# Altium schematic sheet STM32 DIY 飞控板V3.SchDoc
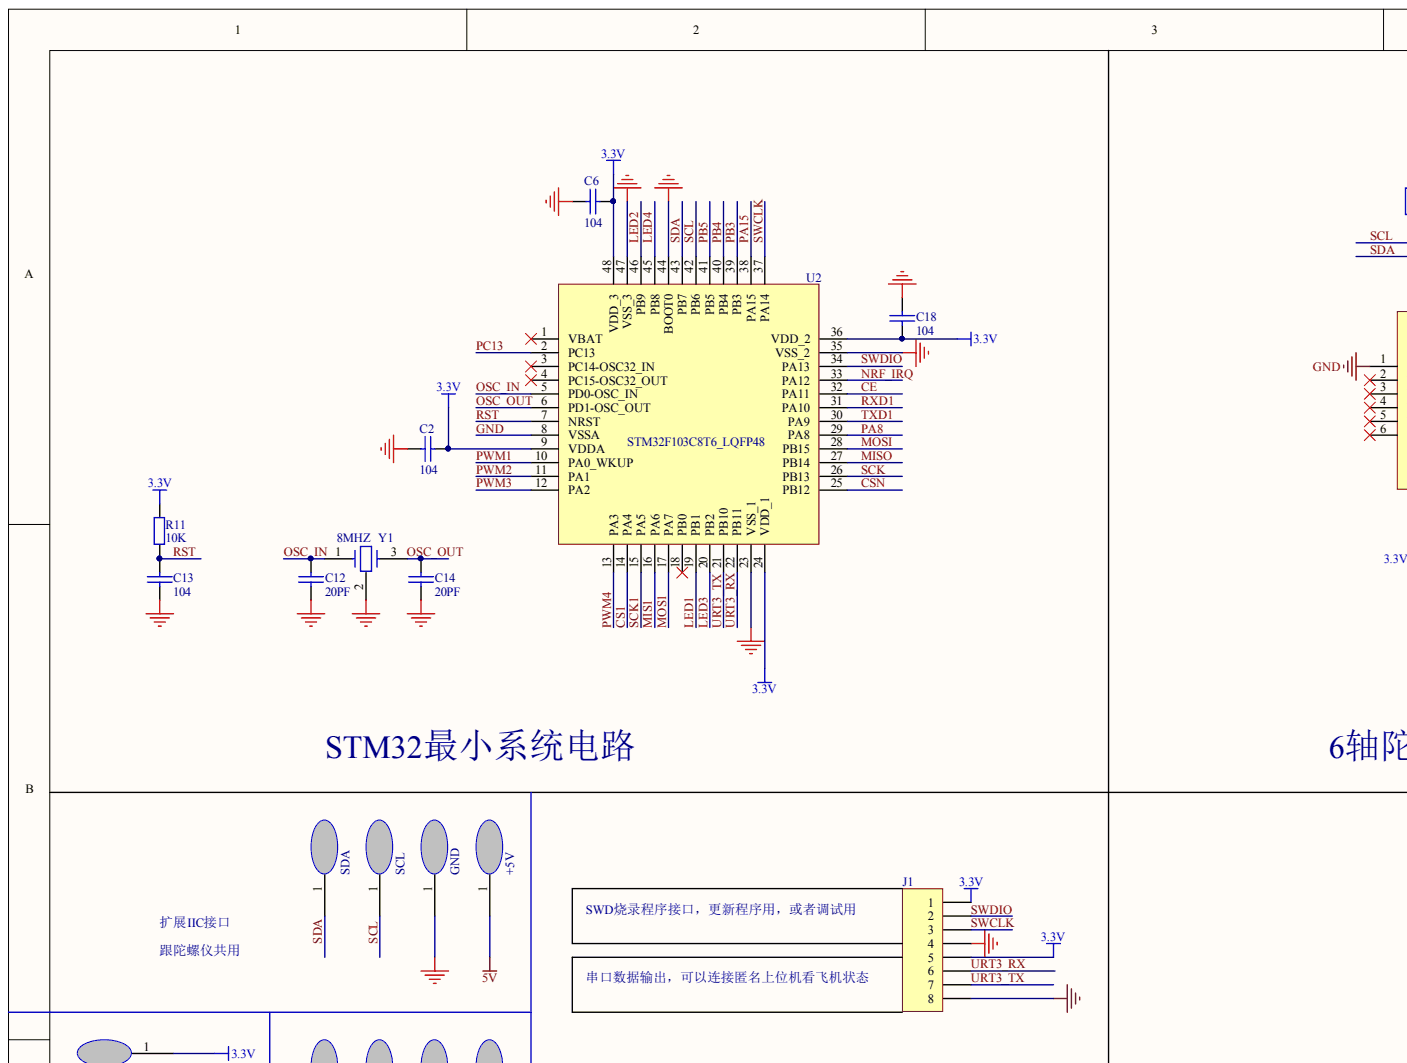
[diagram p0: STM32 DIY 飞控板V3.SchDoc, top left]
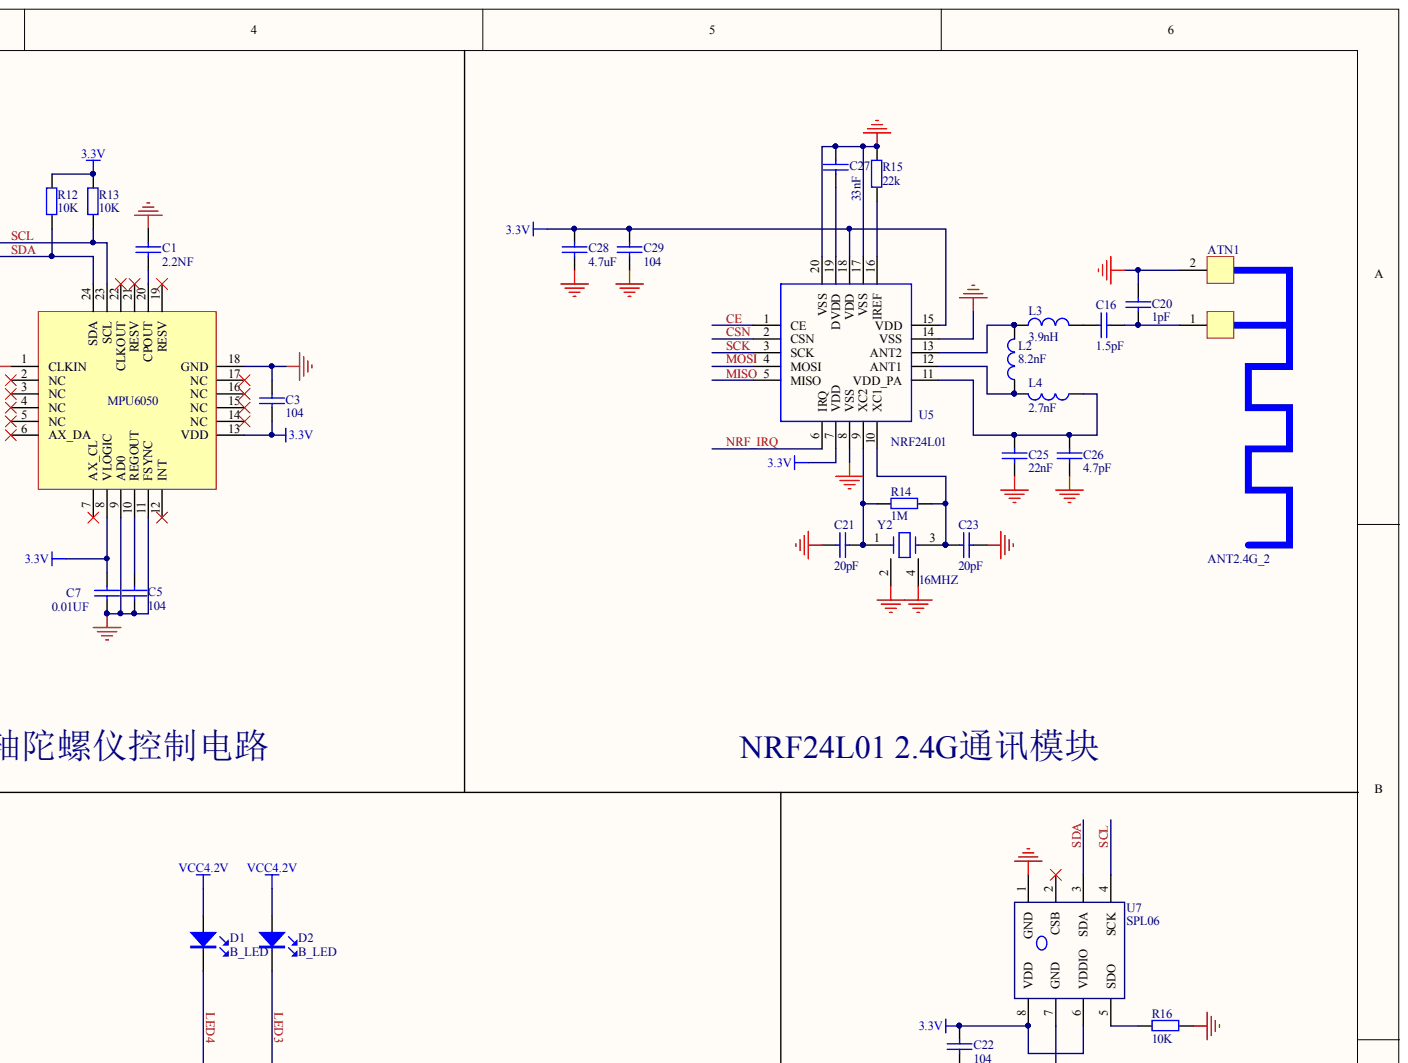
[diagram p1: STM32 DIY 飞控板V3.SchDoc, top right]
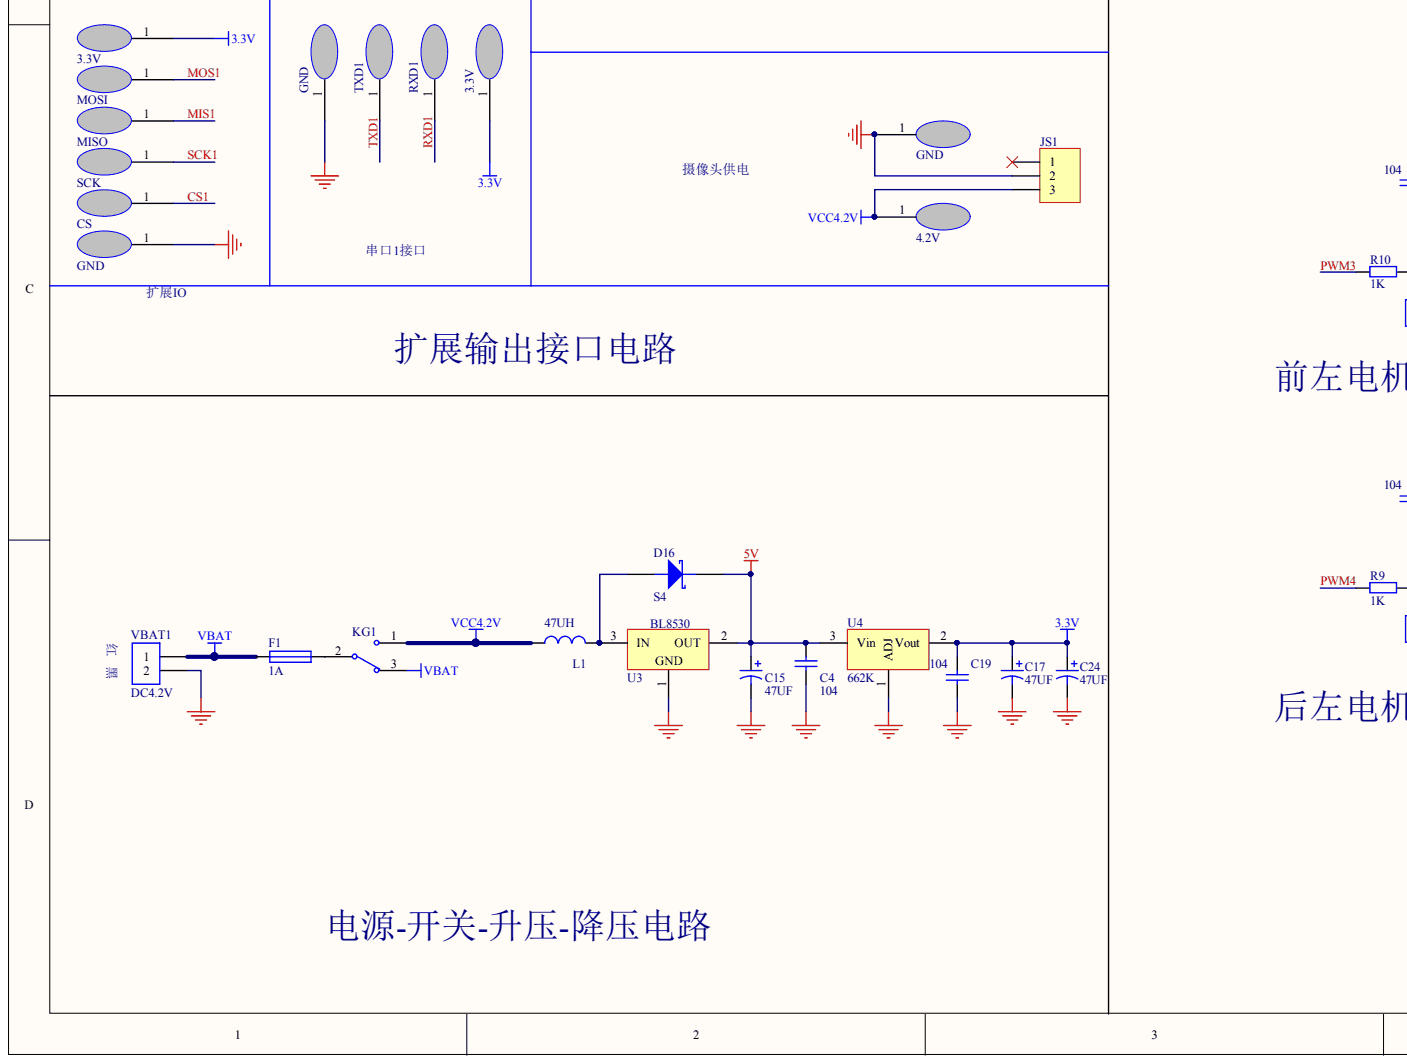
[diagram p2: STM32 DIY 飞控板V3.SchDoc, bottom left]
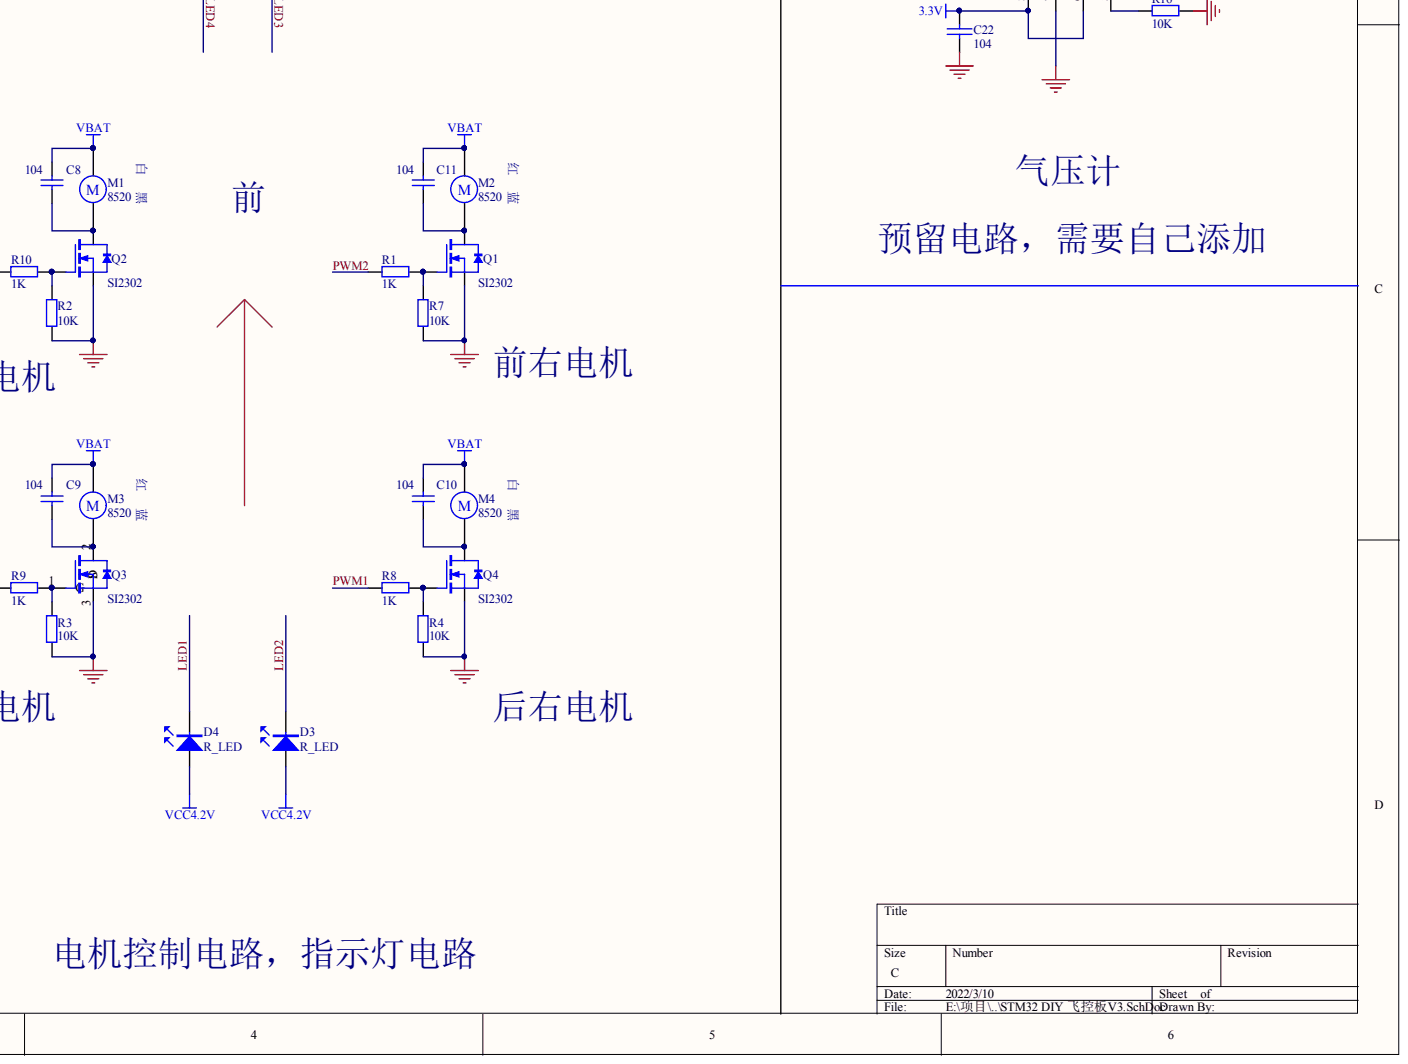
[diagram p3: STM32 DIY 飞控板V3.SchDoc, bottom right]

## Parts
3.3V  IN  [CM11]  (value not drawn)
4.2V  IN  [CM11]  (value not drawn)
11  3.3V  [CM11]
111  GND  [CM11]
+5V  IN  [CM11]  (value not drawn)
ATN1  ANT2.4G_2  [ANT2.4G_2]
C1  2.2NF  [C_0603]
C2  104  [C_0603]
C3  104  [C_0603]
C4  104  [C_0603]
C5  104  [C_0603]
C6  104  [C_0603]
C7  0.01UF  [C_0603]
C8  104  [C_0603]
C9  104  [C_0603]
C10  104  [C_0603]
C11  104  [C_0603]
C12  22PF  [C_0603]  (value not drawn)
C13  104  [C_0603]
C14  22PF  [C_0603]  (value not drawn)
C15  47UF  [C_1206]
C16  1.5pF  [C_0603]
C17  47UF  [C_1206]
C18  104  [C_0603]
C19  104  [C_0603]
C20  1pF  [C_0603]
C21  20pF  [C_0603]
C22  104  [C_0603]
C23  20pF  [C_0603]
C24  47UF  [C_1206]
C25  22nF  [C_0603]
C26  4.7pF  [C_0603]
C27  33nF  [C_0603]
C28  4.7uF  [C_0603]
C29  104  [C_0603]
CS  IN  [CM11]  (value not drawn)
D1  B_LED  [LED0603]
D2  B_LED  [LED0603]
D3  R_LED  [LED0603]
D4  R_LED  [LED0603]
D16  S4  [SOD-123]
F1  1A  [L0805]
GND  IN  [CM11]  (value not drawn)
i  GND  [CM11]
i11  GND  [CM11]
J1  (no value)  [HDR1X8]
JS1  (no value)  [HDR1X3]
KG1  (no value)  [TL36WW15050]
L1  value 47UH  [L_CD43]  (hidden comment: Inductor Chip)
L2  value 8.2nF  [L_CD43]  (hidden comment: Inductor Chip)
L3  value 3.9nH  [L_CD43]  (hidden comment: Inductor Chip)
L4  value 2.7nF  [L_CD43]  (hidden comment: Inductor Chip)
M1  720  [SIP2-A2.0]  (value not drawn)
M2  720  [SIP2-A2.0]  (value not drawn)
M3  720  [SIP2-A2.0]  (value not drawn)
M4  720  [SIP2-A2.0]  (value not drawn)
MISO  IN  [CM11]  (value not drawn)
MOSI  IN  [CM11]  (value not drawn)
Q1  SI2302  [SOT23-123]
Q2  SI2302  [SOT23-123]
Q3  SI2302  [SOT23-123]
Q4  SI2302  [SOT23-123]
R1  1K  [R0603]
R2  10K  [R0603]
R3  10K  [R0603]
R4  10K  [R0603]
R7  10K  [R0603]
R8  1K  [R0603]
R9  1K  [R0603]
R10  1K  [R0603]
R11  10K  [R0603]
R12  10K  [R0603]
R13  10K  [R0603]
R14  1M  [R0603]
R15  22k  [R0603]
R16  10K  [R0603]
RXD1  IN  [CM11]  (value not drawn)
SCK  IN  [CM11]  (value not drawn)
SCL  IN  [CM11]  (value not drawn)
SDA  IN  [CM11]  (value not drawn)
TXD1  IN  [CM11]  (value not drawn)
U1  MPU6050  [QFN24]
U2  STM32F103C8T6_LQFP48  [LQFP48_STM32]
U3  BL8530  [SOT-89-3]
U4  662K  [SOT23-PIN132]
U5  NRF24L01  [LQFP32]
U7  SPL06  [SPL06]
VBAT1  DC4.2V  [SIP2]
Y1  8MHZ  [Y3213]
Y2  16MHZ  [Y3213]

## Nets
3.3V: 3.3V.1 11.1 C2.1 C3.2 C6.2 C7.1 C17.1 C18.2 C19.1 C22.1 C24.1 C28.2 C29.2 J1.1 J1.5 R11.1 R12.1 R13.1 U1.8(VLOGIC) U1.13(VDD) U2.9(VDDA) U2.24(VDD_1) U2.36(VDD_2) U2.48(VDD_3) U4.2 U5.7(VDD) U5.15(VDD) U5.18(VDD) U7.6(VDDIO) U7.8(VDD)
5V: +5V.1 C4.1 C15.1 D16.2 U3.2 U4.3
VBAT: C8.1 C9.1 C10.1 C11.1 F1.1 KG1.3 M1.1 M2.1 M3.1 M4.1 VBAT1.1
VCC4.2V: 4.2V.1 D1.1 D2.1 D3.1 D4.1 JS1.3 KG1.1 L1.1
CE: U2.32(PA11) U5.1(CE)
CS1: CS.1 U2.14(PA4)
CSN: U2.25(PB12) U5.2(CSN)
GND: 111.1 ATN1.2 C1.1 C2.2 C3.1 C4.2 C5.2 C6.1 C7.2 C12.2 C13.2 C14.2 C15.2 C17.2 C18.1 C19.2 C20.1 C21.1 C22.2 C23.1 C24.2 C25.2 C26.2 C27.2 C28.1 C29.1 GND.1 i.1 i11.1 J1.4 J1.8 JS1.2 Q1.3 Q2.3 Q3.3 Q4.3 R2.2 R3.2 R4.2 R7.2 R15.1 R16.2 U1.1(CLKIN) U1.9(AD0) U1.11(FSYNC) U1.18(GND) U2.8(VSSA) U2.23(VSS_1) U2.35(VSS_2) U2.44(BOOT0) U2.47(VSS_3) U3.1 U4.1 U5.8(VSS) U5.14(VSS) U5.17(VSS) U5.20(VSS) U7.1(GND) U7.7(GND) VBAT1.2 Y1.2 Y2.2 Y2.4
LED1: D4.2 U2.19(PB1)
LED2: D3.2 U2.46(PB9)
LED3: D2.2 U2.20(PB2)
LED4: D1.2 U2.45(PB8)
MIS1: MISO.1 U2.16(PA6)
MISO: U2.27(PB14) U5.5(MISO)
MOS1: MOSI.1 U2.17(PA7)
MOSI: U2.28(PB15) U5.4(MOSI)
MPU_INT: U1.12(INT)
NRF_IRQ: U2.33(PA12) U5.6(IRQ)
OSC_IN: C12.1 U2.5(PD0-OSC_IN) Y1.1
OSC_OUT: C14.1 U2.6(PD1-OSC_OUT) Y1.3
P_ADC: U2.18(PB0)
PA8: U2.29(PA8)
PA15: U2.38(PA15)
PB3: U2.39(PB3)
PB4: U2.40(PB4)
PB5: U2.41(PB5)
PC13: U2.2(PC13)
PWM1: R8.1 U2.10(PA0_WKUP)
PWM2: R1.1 U2.11(PA1)
PWM3: R10.1 U2.12(PA2)
PWM4: R9.1 U2.13(PA3)
RST: C13.1 R11.2 U2.7(NRST)
RXD1: RXD1.1 U2.31(PA10)
SCK: U2.26(PB13) U5.3(SCK)
SCK1: SCK.1 U2.15(PA5)
SCL: R13.2 SCL.1 U1.23(SCL) U2.42(PB6) U7.4(SCK)
SDA: R12.2 SDA.1 U1.24(SDA) U2.43(PB7) U7.3(SDA)
SWCLK: J1.3 U2.37(PA14)
SWDIO: J1.2 U2.34(PA13)
TXD1: TXD1.1 U2.30(PA9)
URT3_RX: J1.6 U2.22(PB11)
URT3_TX: J1.7 U2.21(PB10)
NetATN1_1: ATN1.1 C16.1 C20.2
NetC1_2: C1.2 U1.20(CPOUT)
NetC5_1: C5.1 U1.10(REGOUT)
NetC8_2: C8.2 M1.2 Q2.2
NetC9_2: C9.2 M3.2 Q3.2
NetC10_2: C10.2 M4.2 Q4.2
NetC11_2: C11.2 M2.2 Q1.2
NetC16_2: C16.2 L3.2
NetC21_2: C21.2 R14.1 U5.9(XC2) Y2.1
NetC23_2: C23.2 R14.2 U5.10(XC1) Y2.3
NetC25_1: C25.1 C26.1 L4.1 U5.11(VDD_PA)
NetC27_1: C27.1 U5.19(DVDD)
NetD16_1: D16.1 L1.2 U3.3
NetF1_2: F1.2 KG1.2
NetL2_1: L2.1 L4.2 U5.12(ANT1)
NetL2_2: L2.2 L3.1 U5.13(ANT2)
NetQ1_1: Q1.1 R1.2 R7.1
NetQ2_1: Q2.1 R2.1 R10.2
NetQ3_1: Q3.1 R3.1 R9.2
NetQ4_1: Q4.1 R4.1 R8.2
NetR15_2: R15.2 U5.16(IREF)
NetR16_1: R16.1 U7.5(SDO)

Not connected: JS1 1; U1 2(NC) 3(NC) 4(NC) 5(NC) 6(AX_DA) 7(AX_CL) 14(NC) 15(NC) 16(NC) 17(NC) 19(RESV) 21(RESV) 22(CLKOUT); U2 1(VBAT) 3(PC14-OSC32_IN) 4(PC15-OSC32_OUT); U7 2(CSB)

## Library data of the parts
11 111 i i11 = IN: Component Kind: Standard; Library Name: 常用原理图库.SCHLIB
C1-C3 C5-C7 C12-C14 C16 C18 C20-C23 C25-C29 = CAP: Capacitor; Component Kind: Standard
C4 C8-C11 C19 = Cap Chip 1206: Polarized Capacitor (Radial); Component Kind: Standard; Published: 8-Jun-2000; Revision: July-2002: Re-released for DXP Platform.; Value: 104; Library Name: ChapuIntegratedLibrary.IntLib; Publisher: Altium Limited
C15 C17 C24 = Cap Pol1: Polarized Capacitor (Radial); Value: 100pF; Component Kind: Standard; LatestRevisionDate: 17-Jul-2002; LatestRevisionNote: Re-released for DXP Platform.; Library Name: Miscellaneous Devices.IntLib; PackageDescription: Polarized Capacitor (Radial); 2 Leads; PackageReference: RB7.6-15; Published: 8-Jun-2000; Publisher: Altium Limited
D1-D4 = LED: Component Kind: Standard
D16 = DIODE SCHOTTKY: Schottky Diode; Component Kind: Standard
F1 = FUSE1: Fuse; Component Kind: Standard; Library Name: 常用原理图库.SCHLIB
J1 = Header 8: Header, 8-Pin; Component Kind: Standard; LatestRevisionDate: 17-Jul-2002; LatestRevisionNote: Re-released for DXP Platform.; Library Name: Miscellaneous Connectors.IntLib; Publisher: Altium Limited
JS1 = Header 3: Header, 3-Pin; Component Kind: Standard; LatestRevisionDate: 17-Jul-2002; LatestRevisionNote: Re-released for DXP Platform.; Library Name: Miscellaneous Connectors.IntLib; Publisher: Altium Limited
KG1 = SW-SPDT: SPDT Subminiature Toggle Switch, Right Angle Mounting, Vertical Actuation; Component Kind: Standard; ComponentLink1Description: Manufacturer Link; ComponentLink1URL: http://www.apem.com; DatasheetVersion: 05-Dec-2000; LatestRevisionDate: 17-Dec-2003; LatestRevisionNote: Schematic revised, PCB Footprint replaced.; Library Name: Miscellaneous Devices.IntLib; PackageDescription: Switch; 4 Leads; PackageReference: TL36WW15050; Published: 24-Mar-1999; Publisher: Altium Limited
L1-L4 = Inductor Chip: Inductor; Component Kind: Standard; Published: 8-Jun-2000; Revision: July-2002: Re-released for DXP Platform.; Publisher: Altium Limited
  L1: Library Name: ChapuIntegratedLibrary.IntLib
  L2-L4: Library Name: 常用原理图库.SCHLIB
M1-M4 = Motor: Motor, General Kind; Component Kind: Standard; LatestRevisionDate: 17-Jul-2002; LatestRevisionNote: Re-released for DXP Platform.; Library Name: Miscellaneous Devices.IntLib; PackageDescription: Capacitor (Radial); 2 Leads; PackageReference: RB5-10.5; Published: 24-Mar-1999; Publisher: Altium Limited
Q1-Q4 = N_MOS: N-Channel MOSFET; Component Kind: Standard; Library Name: 1218迷你四轴工程_1.SCHLIB
R1 R8-R10 R14 R15 = Res2: Resistor; Value: 10K; Component Kind: Standard; LatestRevisionDate: 17-Jul-2002; LatestRevisionNote: Re-released for DXP Platform.; Published: 8-Jun-2000; Publisher: Altium Limited
R2-R4 R7 R11-R13 R16 = Res2: Resistor; Component Kind: Standard; LatestRevisionDate: 17-Jul-2002; LatestRevisionNote: Re-released for DXP Platform.; Published: 8-Jun-2000; Value: 10K; Publisher: Altium Limited
U2 = STM32_LQFP48: Component Kind: Standard; Library Name: STM32四轴主控原理图.SCHLIB
U3 = HT7750: POSITIVE OUTPUT VOLTAGE; Component Kind: Standard; Library Name: Q222.SCHLIB
U4 = LM317: 3-Terminal Adjustable Positive Voltage Regulator; Component Kind: Standard; Simulation: LM317; Library Name: 稳压器件.SchLib
VBAT1 = CON2: Connector; Component Kind: Standard
Y1 = Y: Component Kind: Standard
Y2 = Y_1: Component Kind: Standard; Library Name: 常用原理图库.SCHLIB
3.3V 4.2V +5V CS GND MISO MOSI RXD1 SCK SCL SDA TXD1 = IN: Component Kind: Standard; Library Name: 常用原理图库.SCHLIB
ATN1 = ANT2.4G_2: Component Kind: Standard; Library Name: 常用原理图库.SCHLIB
U1 = MPU6050: Component Kind: Standard; Library Name: 1218迷你四轴工程_1.SCHLIB
U5 = NRF24L01: Component Kind: Standard; Library Name: 常用原理图库.SCHLIB
U7 = SPL06: Component Kind: Standard; Library Name: 常用原理图库.SCHLIB
